# Altium schematic sheet Sensing.SchDoc
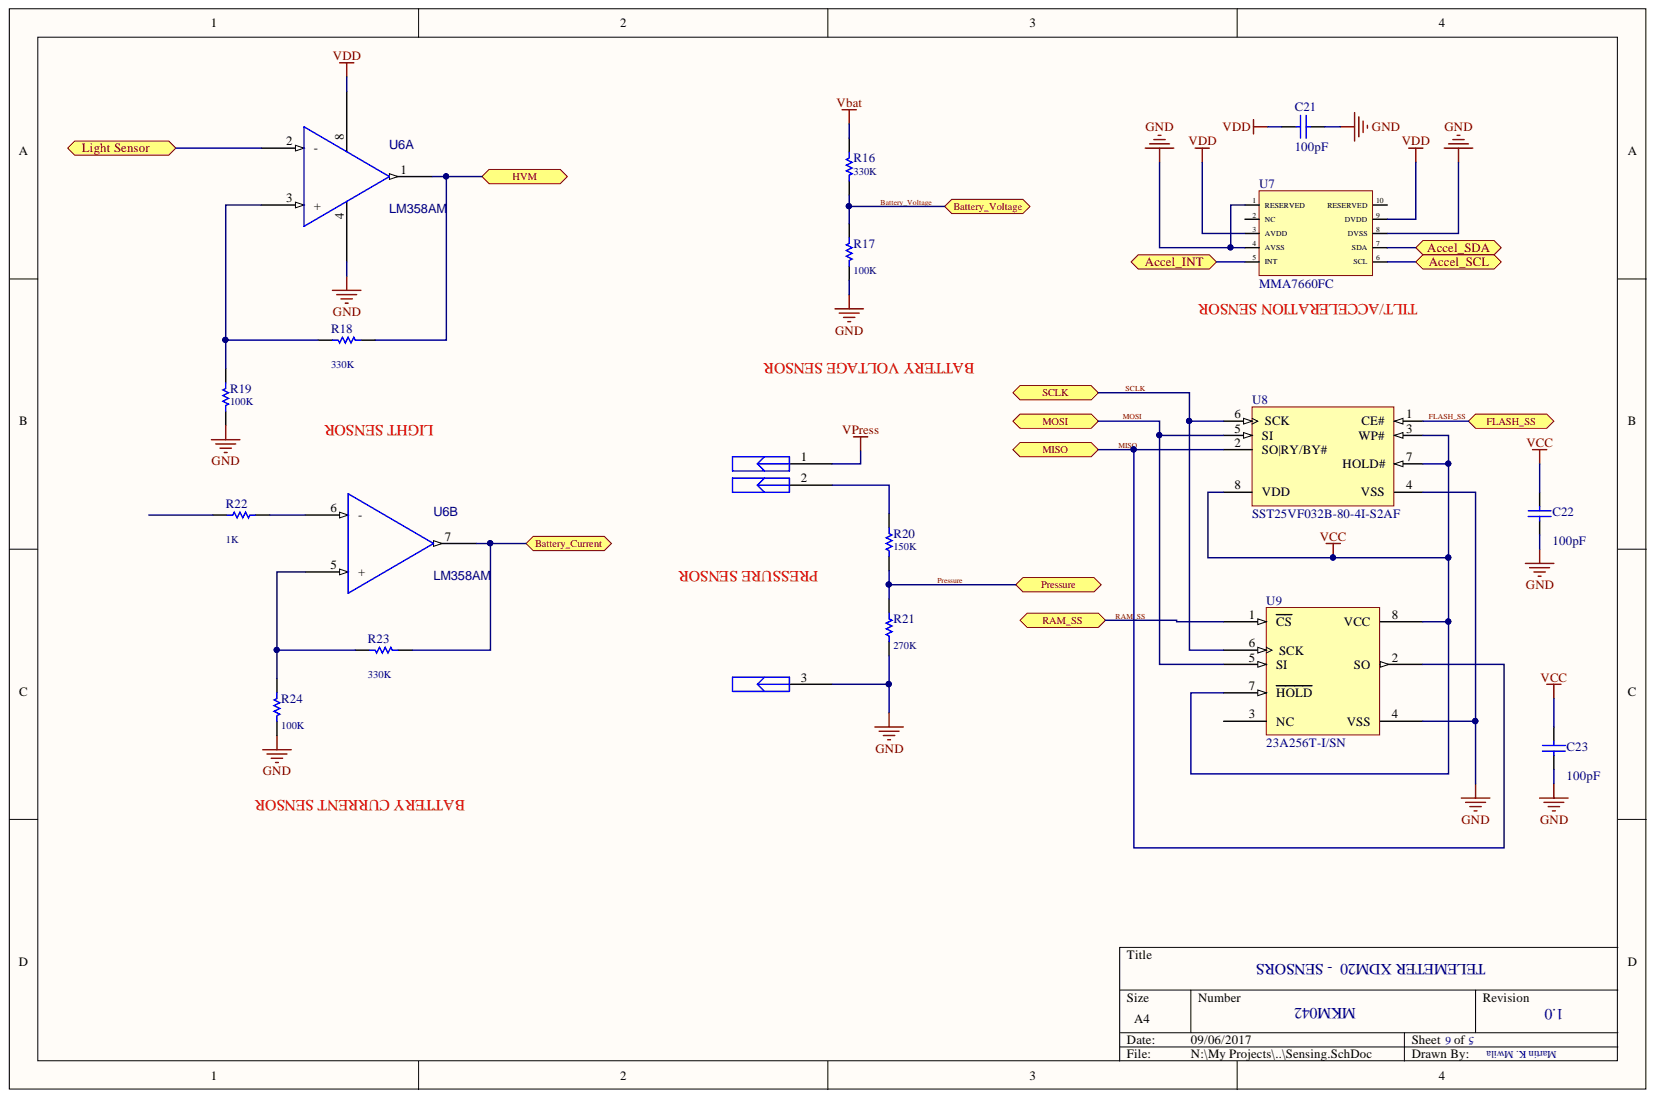
[diagram p0: Sensing.SchDoc]

## Parts
C21  value 100pF  [C-0805]  (hidden comment: Cap 0805)
C22  value 100pF  [C-0805]  (hidden comment: Cap 0805)
C23  value 100pF  [C-0805]  (hidden comment: Cap 0805)
Pressure  CONN-4M  [HO-CHIEN-4W-VERT-P2.54MM]  (value not drawn)  (parts A,B,C)
R16  value 330K  [R-0805 - 330K]  (hidden comment: Resistor - SMD 0805)
R17  value 100K  [R-0805 - 100K]  (hidden comment: Resistor - SMD 0805)
R18  value 330K  [R-0805 - 330K]  (hidden comment: Resistor - SMD 0805)
R19  value 100K  [R-0805 - 100K]  (hidden comment: Resistor - SMD 0805)
R20  value 150K  [R-0805 - 150K]  (hidden comment: Resistor - SMD 0805)
R21  value 270K  [R-0805 - 270K]  (hidden comment: Resistor - SMD 0805)
R22  value 1K  [R-0805 - 1K]  (hidden comment: Resistor - SMD 0805)
R23  value 330K  [R-0805 - 330K]  (hidden comment: Resistor - SMD 0805)
R24  value 100K  [R-0805 - 100K]  (hidden comment: Resistor - SMD 0805)
U6  LM358AM  [SO-8]  (parts A,B)
U7  MMA7660FC  [DFN10_N]
U8  SST25VF032B-80-4I-S2AF  [MCHP-SOIC-S2A8_N]
U9  23A256T-I/SN  [SOIC-SN8_N]

## Ports
Accel_INT, Accel_SCL, Accel_SDA, Battery_Current, Battery_Voltage, FLASH_SS, HVM, Light Sensor, MISO, MOSI, Pressure, RAM_SS, SCLK

## Nets
GND: C21.1 C22.1 C23.1 Pressure.3 R17.2 R19.1 R21.1 R24.2 U6.4 U7.1(RESERVED) U7.4(AVSS) U7.8(DVSS) U8.4(VSS) U9.4(VSS)
Vbat: R16.2
VCC: C22.2 C23.2 U8.3(WP#) U8.7(HOLD#) U8.8(VDD) U9.7(HOLD) U9.8(VCC)
VDD: C21.2 U6.8 U7.3(AVDD) U7.9(DVDD)
VPress: Pressure.1
Accel_INT: U7.5(INT) ; port Accel_INT
Accel_SCL: U7.6(SCL) ; port Accel_SCL
Accel_SDA: U7.7(SDA) ; port Accel_SDA
Battery_Current: R23.2 U6.7 ; port Battery_Current
Battery_Voltage: R16.1 R17.1 ; port Battery_Voltage
FLASH_SS: U8.1(CE#) ; port FLASH_SS
HVM: R18.2 U6.1 ; port HVM
Light Sensor: U6.2(-) ; port Light Sensor
MISO: U8.2(SO|RY/BY#) U9.2(SO) ; port MISO
MOSI: U8.5(SI) U9.5(SI) ; port MOSI
Pressure: R20.2 R21.2 ; port Pressure
RAM_SS: U9.1(CS) ; port RAM_SS
SCLK: U8.6(SCK) U9.6(SCK) ; port SCLK
NetPressure_2: Pressure.2 R20.1
NetR18_1: R18.1 R19.2 U6.3(+)
NetR22_1: R22.1 U6.6(-)
NetR23_1: R23.1 R24.1 U6.5(+)

Not connected: R22 2; U7 2(NC) 10(RESERVED); U9 3(NC)

## Library data of the parts
C21-C23 = Cap 0805: Capacitor SMD; Component Kind: Standard; LatestRevisionDate: 17-Jul-2002; LatestRevisionNote: Re-released for DXP Platform.; Library Name: Capacitors.IntLib; PackageReference: C1206; Published: 8-Jun-2000; Publisher: Altium Limited
Pressure = CONN-4M: Center Friction Lock header; Assy: Loaded; CR: VV; Vendor: Ho-Chien; Vendor P/N: 2506-04; ComponentHeight: 11.20mm; Component Kind: Standard; Library Name: Home Automation.IntLib
R16 R18 R23 = R0805- 330K: Component Kind: Standard; Description: Resistor - SMD 0805; LatestRevisionDate: 17-Jul-2002; LatestRevisionNote: Re-released for DXP Platform.; PackageReference: AXIAL-0.3; Published: 8-Jun-2000; Signal Integrity: Res; Simulation: RESISTOR; Library Name: MKM Resistors.IntLib; Publisher: Altium Limited
R17 R19 R24 = R0805- 100K: Component Kind: Standard; Description: Resistor - SMD 0805; LatestRevisionDate: 17-Jul-2002; LatestRevisionNote: Re-released for DXP Platform.; PackageReference: AXIAL-0.3; Published: 8-Jun-2000; Signal Integrity: Res; Simulation: RESISTOR; Library Name: MKM Resistors.IntLib; Publisher: Altium Limited
R20 = R0805- 150K: Component Kind: Standard; Description: Resistor - SMD 0805; LatestRevisionDate: 17-Jul-2002; LatestRevisionNote: Re-released for DXP Platform.; PackageReference: AXIAL-0.3; Published: 8-Jun-2000; Signal Integrity: Res; Simulation: RESISTOR; Library Name: MKM Resistors.IntLib; Publisher: Altium Limited
R21 = R0805- 270K: Component Kind: Standard; Description: Resistor - SMD 0805; LatestRevisionDate: 17-Jul-2002; LatestRevisionNote: Re-released for DXP Platform.; PackageReference: AXIAL-0.3; Published: 8-Jun-2000; Signal Integrity: Res; Simulation: RESISTOR; Library Name: MKM Resistors.IntLib; Publisher: Altium Limited
R22 = R0805- 1K: Component Kind: Standard; Description: Resistor - SMD 0805; LatestRevisionDate: 17-Jul-2002; LatestRevisionNote: Re-released for DXP Platform.; PackageReference: AXIAL-0.3; Published: 8-Jun-2000; Signal Integrity: Res; Simulation: RESISTOR; Library Name: MKM Resistors.IntLib; Publisher: Altium Limited
U6 = LM358AM: Low Power Dual OPERATIONAL AMPLIFIER; Component Kind: Standard; Library Name: MKM Tech ICs.IntLib
U7 = MMA7660FC: ±1.5 g 3-Axis Accelerometer with Digital Output; Component Kind: Standard; Library Name: MKM Sensors.IntLib
U8 = SST25VF032B-80-4I-S2AF: 32 Mbit SPI Serial Flash, 2.7 to 3.6 V, 80 MHz, -40 to 85 degC, 8-pin SOIC (S2A8), Tube; Manufacturer: Microchip; Component Kind: Standard; ComponentLink1Description: Manufacturer URL; ComponentLink1URL: http://www.microchip.com; ComponentLink2Description: Datasheet; ComponentLink2URL: http://ww1.microchip.com/downloads/en/DeviceDoc/25071A.pdf; ComponentLink3Description: Package Specification; ComponentLink3URL: http://ww1.microchip.com/downloads/en/DeviceDoc/25051A.pdf; ComponentLink4Description: Product URL; ComponentLink4URL: http://www.microchip.com/SST25VF032B; DatasheetVersion: Rev. A; Mounting Technology: Surface Mount; PackageDescription: 8-Lead Plastic Small Outline Intergrated Circuit, 5.275 x 5.275 mm Body, 1.27 mm Pitch; PackageReference: SOIC-S2A8; PackageVersion: Rev. A; PartNumber: SST25VF032B-80-4I-S2AF; Supplier 1: Digi-Key; Supplier 2: Farnell; Supplier 3: Farnell; Supplier 4: Newark; Supplier 5: Mouser; Supplier Part Number 1: SST25VF032B-80-4I-S2AF-ND; Supplier Part Number 2: 1829955; Supplier Part Number 3: 1871811; Supplier Part Number 4: 92R4559; Supplier Part Number 5: 804-25VF032B8IS2AF; Library Name: MKM Tech ICs.IntLib
U9 = 23A256T-I/SN: 256K, 32768x8-bit, 1.8V SPI Serial SRAM, 8-Pin SOIC 3.9mm, Industrial TemperatureTape and Reel; Manufacturer: Microchip; Code_IPC: SOIC127P600-8; Code_JEDEC: MS-012; Component Kind: Standard; ComponentLink1Description: Manufacturer URL; ComponentLink1URL: http://www.microchip.com/; ComponentLink2Description: Datasheet; ComponentLink2URL: http://ww1.microchip.com/downloads/en/DeviceDoc/22100D.pdf; ComponentLink3Description: Package Specification; ComponentLink3URL: http://www.microchip.com/stellent/groups/techpub_sg/documents/packagingspec/en012702.pdf; DatasheetVersion: revD, Apr-2009; Mounting Technology: Surface Mount; PackageDescription: 8-Lead Plastic Small Outline (SN) - Narrow, 3.90mm Body [SOIC]; PackageReference: SOIC-SN8; PackageVersion: revBB, Aug-2009; PartNumber: 23A256T-I/SN; Supplier 1: Digi-Key; Supplier 2: Mouser; Supplier Part Number 1: 23A256T-I/SN-ND; Supplier Part Number 2: 579-23A256T-I/SN; Library Name: MKM Tech ICs.IntLib
